# Altium schematic sheet Microphone-Boards_V1.0_MP34DT05TR.SchDoc
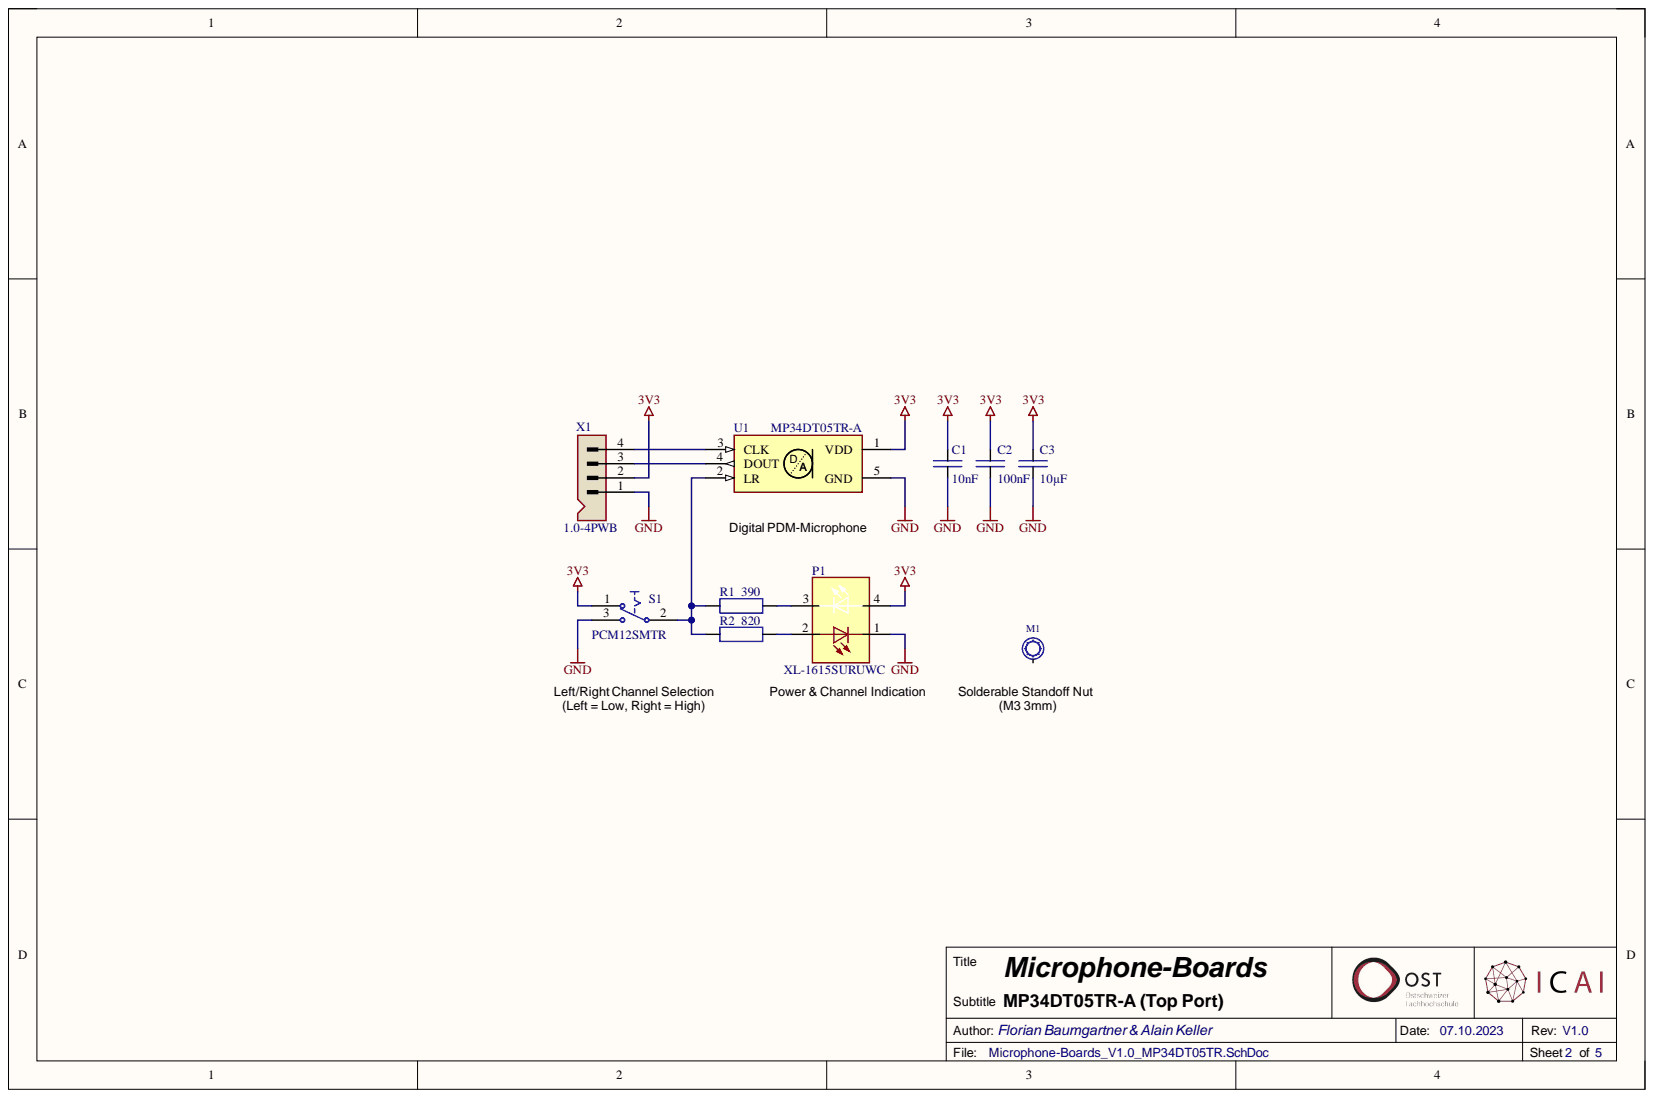
[diagram p0: Microphone-Boards_V1.0_MP34DT05TR.SchDoc]

## Parts
C1  10nF  [SMD Keramikkondensator 0603]
C2  100nF  [SMD Keramikkondensator 0603]
C3  10µF  [SMD Keramikkondensator 1206]
M1  SMTSO-M3-3ET  [SMD Solder-Nut (M3 3mm)]  (value not drawn)
P1  XL-1615SURUWC  [SMD LED 1210]
R1  390  [SMD Widerstand 0603]
R2  820  [SMD Widerstand 0603]
S1  PCM12SMTR  [SMD Switch SPDT (PCM12SMTR)]
U1  MP34DT05TR-A  [SMD Microphone (MP34DT06JTR)]
X1  1.0-4PWB  [SMD Connector (SM04B-SRSS-TB)]

## Nets
3V3: C1.2 C2.2 C3.2 P1.4 S1.1 U1.1(VDD) X1.2
GND: C1.1 C2.1 C3.1 P1.1 S1.3 U1.5(GND) X1.1
NetP1_2: P1.2 R2.2
NetP1_3: P1.3 R1.2
NetR1_1: R1.1 R2.1 S1.2 U1.2(LR)
NetU1_3: U1.3(CLK) X1.4
NetU1_4: U1.4(DOUT) X1.3

Not connected: M1 1

## Library data of the parts
C1 = C-CAPACITOR: CAP-MK; Component Description: Multilayer Ceramic Capacitors; Component Value: 10nF 50V; Component Kind: Standard; Digikey: 445-5662-1-ND; Farnell: 2346966; JLC-Parts: C57112; Library Name: AudioAmp.SCHLIB; Mouser: 77-VJ0603V103ZXAPBC; Unit Price: 0.01
C2 = E6 - 100nF: SMD Ceramic Capacitor E6; Component Description: Multilayer Ceramic Capacitors MLCC; Component Value: 100nF 50V; Component Kind: Standard; ComponentLink1Description: Datasheet; ComponentLink1URL: https://datasheet.lcsc.com/lcsc/1809301912_YAGEO-CC0603KRX7R9BB104_C14663.pdf; Digikey: 445-6899-1-ND; Farnell: 2210822; JLC-Parts: C14663; Library Name: Ceramic Capacitors-E6.SchLib; Mouser: 810-CGA2B3X7R1H104K; Unit Price: 0.01
C3 = E6 - 10uF: SMD Ceramic Capacitor E6; Component Description: Multilayer Ceramic Capacitors MLCC; Component Value: 10µF 50V; Component Kind: Standard; ComponentLink1Description: Datasheet; ComponentLink1URL: https://datasheet.lcsc.com/lcsc/1810191219_Samsung-Electro-Mechanics-CL10A106KP8NNNC_C19702.pdf; Digikey: 587-3258-1-ND; Farnell: 2113070; JLC-Parts: C13585; Library Name: Ceramic Capacitors-E6.SchLib; Mouser: 963-LMK107BBJ106MALT; Unit Price: 0.01
M1 = M-Spacer_M3_3mm: Solderable Standoff Nut; Component Value: M3 3mm; Component Description: Solderable Standoff Nut; Component Kind: Standard; ComponentLink1Description: Datasheet; ComponentLink1URL: https://datasheet.lcsc.com/lcsc/2112061830_Sinhoo-SMTSO3030CTJ_C2915308.pdf; JLC-Parts: C2915308; Mouser: 153-SMTSO-M3-3ET; Unit Price: 0.05; Library Name: Velotour_2022.SCHLIB
P1 = P-XL-1615SURUWC: LED Red/White; Stückpreis: 0.02; Bauteilwert: XL-1615SURUWC; Beschreibung: LED Red/White; Component Kind: Standard; ComponentLink1Description: Datasheet; ComponentLink1URL: https://datasheet.lcsc.com/lcsc/2211030000_XINGLIGHT-XL-1615SURUWC_C965837.pdf; JLC-Parts: C965837; Library Name: Microphone-Boards.SCHLIB
R1 = R-RESISTOR: SMD-Widerstand 1/4W; Component Description: Thick Film Chip Resistor; Component Value: 390 (75V, 100mW, 1%); Component Kind: Standard; Digikey: P390HCT-ND; Farnell: 2303111; JLC-Parts: C23151; Mouser: 667-ERJ-3EKF3900V; Unit Price: 0.06; Library Name: Backplane_1.SCHLIB
R2 = R-RESISTOR: SMD-Widerstand 1/4W; Component Description: Thick Film Chip Resistor; Component Value: 820 (75V, 100mW, 1%); Component Kind: Standard; Digikey: P820HCT-ND; Farnell: 2303136; JLC-Parts: C23253; Mouser: 667-ERJ-3EKF8200V; Unit Price: 0.06; Library Name: Backplane_1.SCHLIB
S1 = S-PCM12SMTR: Miniature Slide Switch; aliExpress: 33002042977; Bauteilwert: PCM12SMTR (SPDT); Stückpreis: 0.77; Beschreibung: Miniature Slide Switch; Component Kind: Standard; Digikey: 401-2016-1-ND; Farnell: 9575146; Library Name: Custom ST-Link.SchLib; Mouser: 611-PCM12SMTR
U1 = U-MP34DT05TR-A: Digital PDM MEMS Microphone (Top Port); Component Description: Digital PDM MEMS Microphone (Top Port); Component Kind: Standard; Component Value: MP34DT05TR-A; ComponentLink1Description: Datasheet; ComponentLink1URL: https://datasheet.lcsc.com/lcsc/2106070037_STMicroelectronics-MP34DT05TR-A_C2688664.pdf; Digikey: 497-17809-1-ND; Farnell: 2851942; JLC-Parts: C2688664; Library Name: Microphone-Boards.SCHLIB; Mouser: 511-MP34DT05TR-A; Unit Price: 1.50
X1 = X-Stiftleiste (4-Fach): Wire To Board Connector (1x4P 1.0mm); Component Description: Wire To Board Connector (1x4P 1.0mm); Component Kind: Standard; Component Value: 1.0-4PWB; Digikey: 455-SM04B-SRSS-TBCT-ND; Farnell: 1830839; JLC-Parts: C2905006; Unit Price: 0.03; Library Name: Audio-Beamformer.SCHLIB
